# Altium schematic sheet MPPT_POWER.SchDoc
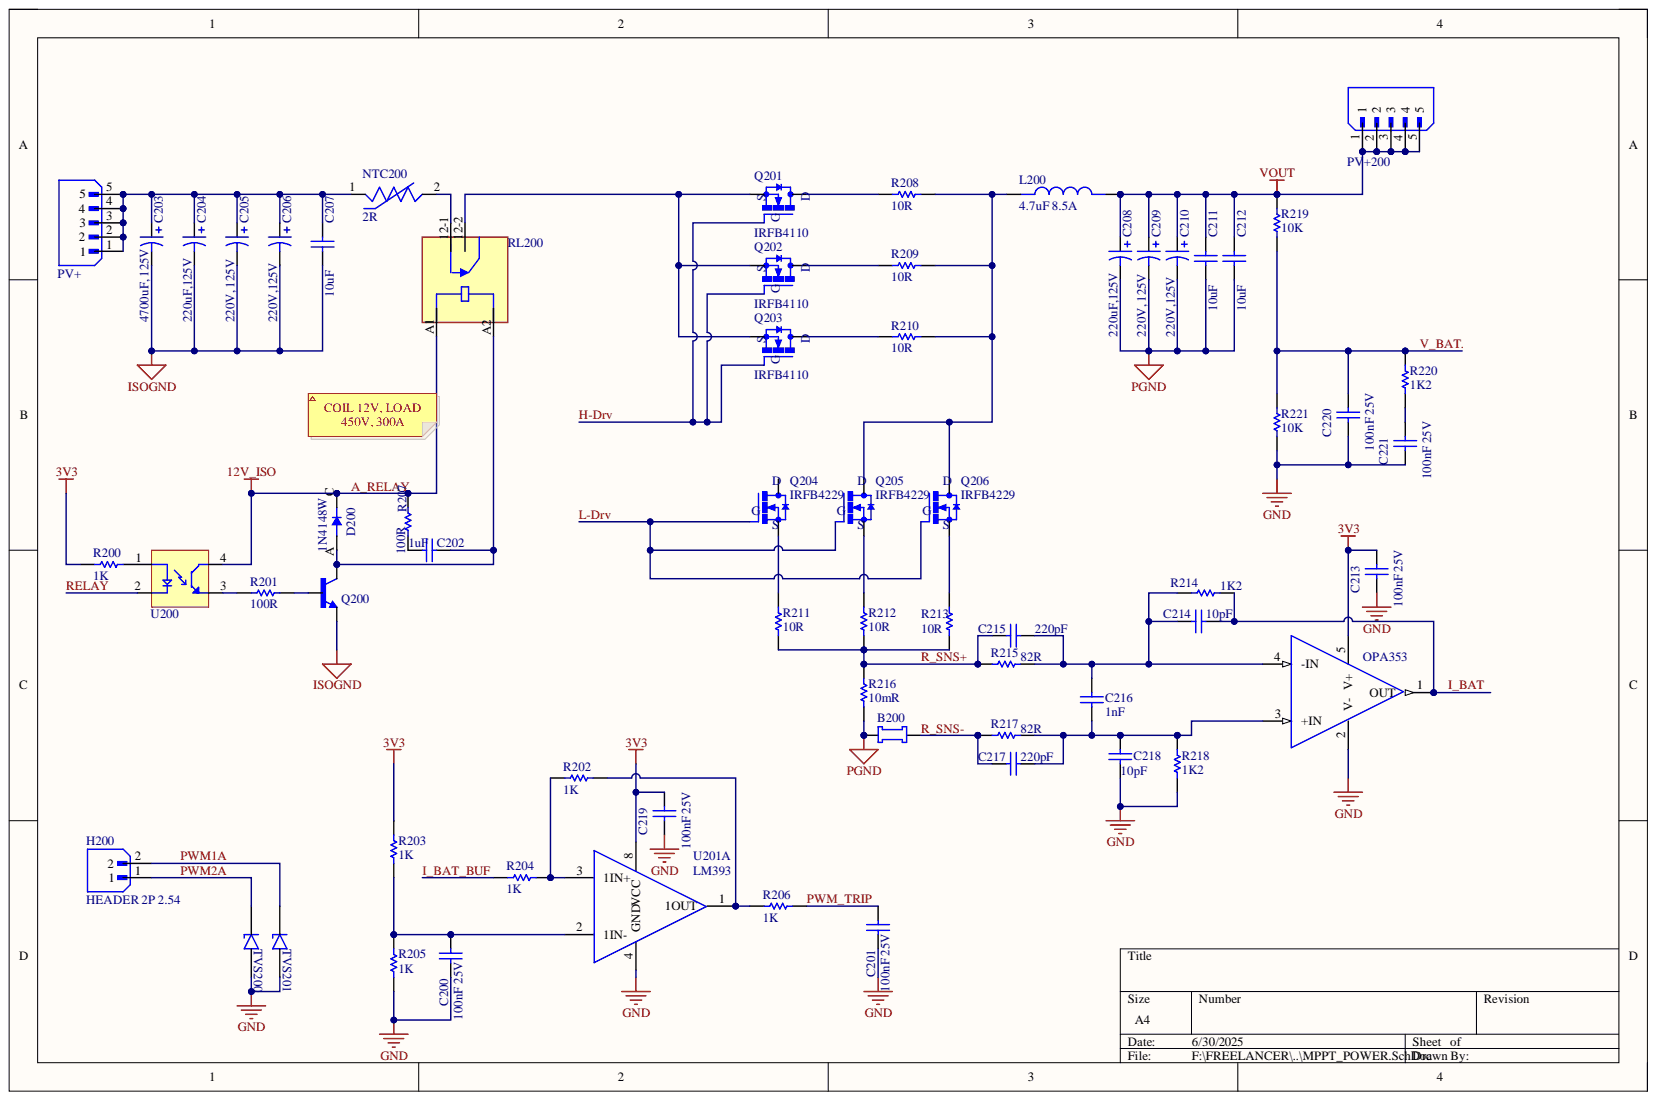
[diagram p0: MPPT_POWER.SchDoc]

## Parts
*  OPA353
B200  Narrow Neck Bridge  [Narrow Bridge]  (value not drawn)
C200  100nF 25V  [Cap cer 0603]
C201  100nF 25V  [Cap cer 0603]
C202  1uF  [Cap cer 0603]
C203  value 4700uF, 125V  [C0805]  (hidden comment: Cap Pol3)
C204  value 220uF,125V  [C0805]  (hidden comment: Cap Pol3)
C205  value 220V, 125V  [C0805]  (hidden comment: Cap Pol3)
C206  value 220V,125V  [C0805]  (hidden comment: Cap Pol3)
C207  10uF  [Cap cer 0603]
C208  value 220uF,125V  [C0805]  (hidden comment: Cap Pol3)
C209  value 220V, 125V  [C0805]  (hidden comment: Cap Pol3)
C210  value 220V,125V  [C0805]  (hidden comment: Cap Pol3)
C211  10uF  [Cap cer 0603]
C212  10uF  [Cap cer 0603]
C213  100nF 25V  [Cap cer 0603]
C214  10pF  [Cap cer 0603]
C215  220pF  [Cap cer 0603]
C216  1nF  [Cap cer 0603]
C217  220pF  [Cap cer 0603]
C218  10pF  [Cap cer 0603]
C219  100nF 25V  [Cap cer 0603]
C220  100nF 25V  [Cap cer 0603]
C221  100nF 25V  [Cap cer 0603]
D200  1N4148W  [1N4148]
H200  HEADER 2P 2.54  [HEADER 2P 2.544]
L200  4.7uF 8.5A  [Inductor 4.7uF 8.5A]
NTC200  2R
PV+  B5B-XH-A(LF)(SN)  [XH2.54 5p]  (value not drawn)
PV+200  B5B-XH-A(LF)(SN)  [XH2.54 5p]  (value not drawn)
Q200  MMBT3904  [MMBT3904]  (value not drawn)
Q201  IRFB4110
Q202  IRFB4110
Q203  IRFB4110
Q204  IRFB4229
Q205  IRFB4229
Q206  IRFB4229
R200  1K  [Res 0603]
R201  100R  [Res 0603]
R202  1K  [Res 0603]
R203  1K  [Res 0603]
R204  1K  [Res 0603]
R205  1K  [Res 0603]
R206  1K  [Res 0603]
R207  100R  [Res 0603]
R208  10R  [Res 0603]
R209  10R  [Res 0603]
R210  10R  [Res 0603]
R211  10R  [Res 0603]
R212  10R  [Res 0603]
R213  10R  [Res 0603]
R214  1K2  [Res 0603]
R215  82R  [Res 0603]
R216  10mR  [Res 0603]
R217  82R  [Res 0603]
R218  1K2  [Res 0603]
R219  10K  [Res 0603]
R220  1K2  [Res 0603]
R221  10K  [Res 0603]
RL200  (no value)
TVS200  SMCJ5.0A-13-F  [TVS 5V]  (value not drawn)
TVS201  SMCJ5.0A-13-F  [TVS 5V]  (value not drawn)
U200  PC817X1NIP1B  [PC817]  (value not drawn)
U201  LM393  [lm393]  (part A)

## Nets
3V3: *.5(V+) C213.2 C219.2 R200.1 R203.2 U201.8(VCC)
GND: *.2(V-) C200.1 C201.1 C213.1 C218.2 C219.1 C220.1 C221.1 R205.1 R218.1 R221.1 TVS200.2 TVS201.2 U201.4(GND)
ISOGND: C203.2 C204.2 C205.2 C206.2 C207.2 Q200.2
PGND: B200.1 C208.2 C209.2 C210.2 C211.2 C212.2 R216.2
VOUT: C208.1 C209.1 C210.1 C211.1 C212.1 L200.2 PV+200.1 PV+200.2 PV+200.3 PV+200.4 PV+200.5 R219.2
A_RELAY: D200.C R207.2 RL200.A1 U200.4
H-Drv: Q201.1 Q202.1 Q203.1
I_BAT: *.1(OUT) C214.2 R214.1
I_BAT_BUF: R204.1
L-Drv: Q204.1 Q205.1 Q206.1
PWM1A: H200.2 TVS201.1
PWM2A: H200.1 TVS200.1
PWM_TRIP: C201.2 R206.2
R_SNS+: C215.2 R211.1 R212.1 R213.1 R215.1 R216.1
R_SNS-: B200.2 C217.2 R217.1
RELAY: U200.2
V_BAT.: C220.2 R219.1 R220.1 R221.2
Net*_3: *.3(+IN) C216.2 C217.1 C218.1 R217.2 R218.2
Net*_4: *.4(-IN) C214.1 C215.1 C216.1 R214.2 R215.2
NetC200_2: C200.2 R203.1 R205.2 U201.2(1IN-)
NetC202_1: C202.1 D200.A Q200.3 RL200.A2
NetC202_2: C202.2 R207.1
NetC203_1: C203.1 C204.1 C205.1 C206.1 C207.1 NTC200.1 PV+.1 PV+.2 PV+.3 PV+.4 PV+.5
NetC221_2: C221.2 R220.2
NetL200_1: L200.1 Q205.2 Q206.2 R208.2 R209.2 R210.2
NetNTC200_2: NTC200.2 RL200.12-1
NetQ200_1: Q200.1 R201.2
NetQ201_2: Q201.2 R208.1
NetQ201_3: Q201.3 Q202.3 Q203.3 RL200.12-2
NetQ202_2: Q202.2 R209.1
NetQ203_2: Q203.2 R210.1
NetQ204_3: Q204.3 R211.2
NetQ205_3: Q205.3 R212.2
NetQ206_3: Q206.3 R213.2
NetR200_2: R200.2 U200.1
NetR201_1: R201.1 U200.3
NetR202_1: R202.1 R204.2 U201.3(1IN+)
NetR202_2: R202.2 R206.1 U201.1(1OUT)

Not connected: Q204 2

## Library data of the parts
C200-C202 C207 C211-C221 = GCM188R71E104KA57D: Multilayer Ceramic Capacitor, 0.1 uF, 25 V, ï¿½ 10%, X7R, 0603 [1608 Metric]; Manufacturer: Murata; Category: Ceramic Capacitors; Component Kind: Standard; ComponentLink1Description: https://datasheet.ciiva.com/18183/getdatasheetpartid-301637-18183609.pdf?src-supplier=Verical; ComponentLink1URL: https://datasheet.ciiva.com/18183/getdatasheetpartid-301637-18183609.pdf?src-supplier=Verical; ComponentLink2Description: https://datasheet.ciiva.com/3778/11371gcm188r71e104ka5723-3778030.pdf?src-supplier=Arrow+Electronics; ComponentLink2URL: https://datasheet.ciiva.com/3778/11371gcm188r71e104ka5723-3778030.pdf?src-supplier=Arrow+Electronics; ComponentLink3Description: https://datasheet.ciiva.com/6270/s0717e-6270619.pdf?src-supplier=Digikey; ComponentLink3URL: https://datasheet.ciiva.com/6270/s0717e-6270619.pdf?src-supplier=Digikey; ComponentLink4Description: https://datasheet.ciiva.com/6270/s0717e-6270620.pdf?src-supplier=Digikey; ComponentLink4URL: https://datasheet.ciiva.com/6270/s0717e-6270620.pdf?src-supplier=Digikey; ComponentLink5Description: https://datasheet.ciiva.com/6270/s0717e-6270621.pdf?src-supplier=Digikey; ComponentLink5URL: https://datasheet.ciiva.com/6270/s0717e-6270621.pdf?src-supplier=Digikey; Lead Free: 5697LeadFree; Library Name: POWER_PIN_CAMERA.SchLib; Manufacturer Part Number: GCM188R71E104KA57D; Pricing 1: 1=0.26, 10=0.151, 50=0.151, 100=0.097, 1000=0.068, 10000=0.046 (USD); RoHS: Yes; Stock 1: 52188; Supplier 1: Mouser; Supplier Part Number 1: 81-GCM188R71E104KA7D
C203-C206 C208-C210 = Cap Pol3: Polarized Capacitor (Surface Mount); Component Kind: Standard; LatestRevisionDate: 29-May-2009; LatestRevisionNote: IPC-7351 Footprint Added.; Library Name: Miscellaneous Devices.IntLib; PackageDescription: Chip Capacitor; PackageReference: C0805; Published: 8-Jun-2000; Signal Integrity: Cap Pol; Simulation: CAP; Publisher: Altium Limited
D200 = 1N4148W-7-F: Small Signal Diode, Single, 100 V, 300 Ma, 1.25 V, 4 Ns, 2 A Rohs Compliant: Yes; Manufacturer: Diodes; Average Rectified Current: 300mA; Capacitance: 2pF; Current Rating: 150mA; Forward Voltage: 1.25V; Max Forward Surge Current (Ifsm): 2A; Max Repetitive Reverse Voltage (Vrrm): 100V; Max Reverse Current: 1uA; Polarity: Standard; Power Dissipation: 400mW; Recovery Time: 4ns; Voltage Rating (DC): 75V; Category: Rectifier Diodes; Component Kind: Standard; ComponentLink1Description: https://datasheet.ciiva.com/8303/ds30086-8303197.pdf?src-supplier=Avnet; ComponentLink1URL: https://datasheet.ciiva.com/8303/ds30086-8303197.pdf?src-supplier=Avnet; ComponentLink2Description: https://datasheet.ciiva.com/911/ds30086-911735.pdf?src-supplier=Digikey; ComponentLink2URL: https://datasheet.ciiva.com/911/ds30086-911735.pdf?src-supplier=Digikey; ComponentLink3Description: https://datasheet.ciiva.com/911/ds30086-911736.pdf?src-supplier=Digikey; ComponentLink3URL: https://datasheet.ciiva.com/911/ds30086-911736.pdf?src-supplier=Digikey; ComponentLink4Description: https://datasheet.ciiva.com/911/ds30086-911734.pdf?src-supplier=Digikey; ComponentLink4URL: https://datasheet.ciiva.com/911/ds30086-911734.pdf?src-supplier=Digikey; ComponentLink5Description: https://datasheet.ciiva.com/1179/1915529-1179304.pdf?src-supplier=Element14; ComponentLink5URL: https://datasheet.ciiva.com/1179/1915529-1179304.pdf?src-supplier=Element14; ComponentLink6Description: https://datasheet.ciiva.com/22695/1915529-22695239.pdf?src-supplier=Element14; ComponentLink6URL: https://datasheet.ciiva.com/22695/1915529-22695239.pdf?src-supplier=Element14; ComponentLink7Description: https://datasheet.ciiva.com/8300/ds30086-8300392.pdf?src-supplier=Avnet; ComponentLink7URL: https://datasheet.ciiva.com/8300/ds30086-8300392.pdf?src-supplier=Avnet; ComponentLink8Description: https://datasheet.ciiva.com/15219/getdatasheetpartid-110030-15219548.pdf?src-supplier=Verical; ComponentLink8URL: https://datasheet.ciiva.com/15219/getdatasheetpartid-110030-15219548.pdf?src-supplier=Verical; ComponentLink9Description: https://datasheet.ciiva.com/4435/ds30086-4435128.pdf?src-supplier=Mouser; ComponentLink9URL: https://datasheet.ciiva.com/4435/ds30086-4435128.pdf?src-supplier=Mouser; Forward Current: 150mA; Height: 1.25mm; Lead Free: Lead Free; Length: 2.85mm; Library Name: POWER_PIN_CAMERA.SchLib; Manufacturer Part Number: 1N4148W-7-F; Max Operating Temperature: 150°C; Max Reverse Voltage (DC): 100V; Max Surge Current: 2A; Min Operating Temperature: -65°C; Mount: Surface Mount; Number of Pins: 2; Output Current: 150mA; Package Quantity: 3000; Packaging: Tape and Reel; Peak Non-Repetitive Surge Current: 2A; Power Rating: 400mW; Pricing 1: 9000=0.0099, 15000=0.0099, 21000=0.0099, 30000=0.0099, 75000=0.0099 (USD); Radiation Hardening: No; Reach SVHC Compliant: No; Reverse Recovery Time: 4ns; Reverse Recovery Time-Max: 4ns; Reverse Voltage: 100V; RoHS: Yes; RoHS Compliant: Yes; Series: -; Stock 1: 4485000; Supplier 1: Arrow Electronics; Supplier Part Number 1: 1N4148W-7-F; Voltage: 100V; Weight: 0.000353oz; Width: 1.7mm
H200 = B2B-XH-A(LF)(SN): CONNECTOR HEADER, XH SERIES, 2 POSITION, 2.50MM, Press-Fit Solder, Detent Lock, Natural; Manufacturer: JST; Contact Resistance: 20mR; Current Rating: 3A; Insulation Resistance: 1GR; Max Voltage Rating (AC): 250V; Number of Contacts: 2; Pitch: 2.5mm; Wire/Cable Gauge: 22AWG; Category: Headers and Wire Housings; Color: Natural; Component Kind: Standard; ComponentLink1Description: https://datasheet.ciiva.com/11746/0900766b8082653e-11746979.pdf?src-supplier=Rs; ComponentLink1URL: https://datasheet.ciiva.com/11746/0900766b8082653e-11746979.pdf?src-supplier=Rs; ComponentLink2Description: https://datasheet.ciiva.com/26894/getdatasheetpartid-385291-26894760.pdf?src-supplier=Verical; ComponentLink2URL: https://datasheet.ciiva.com/26894/getdatasheetpartid-385291-26894760.pdf?src-supplier=Verical; ComponentLink3Description: https://datasheet.ciiva.com/26894/getdatasheetpartid-640357-26894434.pdf?src-supplier=Verical; ComponentLink3URL: https://datasheet.ciiva.com/26894/getdatasheetpartid-640357-26894434.pdf?src-supplier=Verical; ComponentLink4Description: https://datasheet.ciiva.com/8052/5448-8052024.pdf?src-supplier=Element14; ComponentLink4URL: https://datasheet.ciiva.com/8052/5448-8052024.pdf?src-supplier=Element14; Contact Material: Brass; Contact Plating: Tin,Lead,Copper; Contact Type: Male Pin; Depth: 5.75mm; Fastening Type: Detent; Housing Color: White; Housing Material: Polyamide; Lead Free: Lead Free; Lead Pitch: 2.5mm; Length: 7.4mm; Manufacturer Part Number: B2B-XH-A(LF)(SN); Max Current Rating: 3A; Max Operating Temperature: 85°C; Max Voltage Rating (DC): 250V; Min Operating Temperature: -25°C; Mount: Through Hole; Number of Positions: 2; Number of Rows: 1; Orientation: Straight; Packaging: Bulk; Pricing 1: 1=0,0544, 500=0,0528, 1500=0,0514, 5000=0,0499, 15000=0,0472 (USD); Reach SVHC Compliant: No; RoHS: Yes; Series: XH; Stock 1: 138390; Supplier 1: Future Electronics; Supplier Part Number 1: 1638840; Termination: Solder; Voltage Rating: 250V; Voltage Rating (AC): 250V; Weight: 28.349523g; Library Name: MPPT_600W.SchLib
L200 = Inductor 4.7uF 8.5A: FIXED IND 4.7UH 8.5A 18.4MOHM; Manufacturer: Abracon; Manufacturer Part Number: ASPIAIG-F6050-4R7M-T; Category: Fixed Inductors; Component Kind: Standard; ComponentLink1Description: https://datasheet.octopart.com/ASPIAIG-F6050-4R7M-T-Abracon-datasheet-113089578.pdf?src-supplier=Future+Electronics; ComponentLink1URL: https://datasheet.octopart.com/ASPIAIG-F6050-4R7M-T-Abracon-datasheet-113089578.pdf?src-supplier=Future+Electronics; ComponentLink2Description: https://abracon.com/Magnetics/power/ASPIAIG-F.pdf?src-supplier=Digikey; ComponentLink2URL: https://abracon.com/Magnetics/power/ASPIAIG-F.pdf?src-supplier=Digikey; Library Name: POWER_PIN_CAMERA.SchLib; Pricing 1: 1=1.3, 10=1.07, 50=1.07, 100=0.828, 1000=0.668, 10000=0.625 (USD); RoHS: No; Stock 1: 897; Supplier 1: Mouser; Supplier Part Number 1: 815-SPIAIGF60504.7MT
NTC200 = NTC: Component Kind: Standard; Library Name: MPPT_600W.SchLib
PV+ PV+200 = B5B-XH-A(LF)(SN): Connector Header, XH Series, 5 Position, 2.50mm, Through Hole, Press-Fit, Solder, Natural; Manufacturer: JST; Contact Post Length: 0.134inch; Gender: 29Gender; Mated Stacking Heights: 9.8mm; Number of Positions: 5; Voltage Rating: 250V; Category: Headers and Wire Housings; Component Kind: Standard; ComponentLink1Description: https://datasheet.ciiva.com/26894/getdatasheetpartid-455618-26894798.pdf?src-supplier=Verical; ComponentLink1URL: https://datasheet.ciiva.com/26894/getdatasheetpartid-455618-26894798.pdf?src-supplier=Verical; ComponentLink2Description: https://datasheet.ciiva.com/11746/0900766b8082f55f-11746654.pdf?src-supplier=Rs; ComponentLink2URL: https://datasheet.ciiva.com/11746/0900766b8082f55f-11746654.pdf?src-supplier=Rs; ComponentLink3Description: https://datasheet.ciiva.com/26894/getdatasheetpartid-640991-26894479.pdf?src-supplier=Verical; ComponentLink3URL: https://datasheet.ciiva.com/26894/getdatasheetpartid-640991-26894479.pdf?src-supplier=Verical; ComponentLink4Description: https://datasheet.ciiva.com/724/exh-724390.pdf?src-supplier=Digikey; ComponentLink4URL: https://datasheet.ciiva.com/724/exh-724390.pdf?src-supplier=Digikey; ComponentLink5Description: https://datasheet.ciiva.com/8052/1684882-8052063.pdf?src-supplier=Element14; ComponentLink5URL: https://datasheet.ciiva.com/8052/1684882-8052063.pdf?src-supplier=Element14; Connector Type: Header; Contact Finish - Mating: TIN; Contact Material: Brass; Contact Shape: Square; Contact Type: Male Pin; Fastening Type: Detent; Flammability Rating: UL94 V-0; Insulation Color: Natural; Insulation Height: 0.276inch; Insulation Material: Nylon; Lead Free: Lead Free; Manufacturer Part Number: B5B-XH-A(LF)(SN); Max Operating Temperature: 85°C; Min Operating Temperature: -25°C; Mount: Through Hole; Number of Rows: 1; Packaging: Bulk; Pricing 1: 1=0,106, 250=0,103, 1000=0,0996, 2500=0,0972, 10000=0,0916 (USD); RoHS: Yes; Series: XH; Stock 1: 3816; Supplier 1: Future Electronics; Supplier Part Number 1: 5006945; Termination: Press-Fit; Weight: 28.349523g; Library Name: MPPT_600W.SchLib
Q200 = MMBT3904: MMBT3904 Series 40 V CE Breakdown 0.2 A NPN General Purpose Amplifier - SOT-23; Manufacturer: onsemi; Collector Base Voltage (VCBO): 60V; Collector Emitter Breakdown Voltage: 40V; Collector Emitter Saturation Voltage: 300mV; Current Rating: 200mA; Emitter Base Voltage (VEBO): 6V; hFE Min: 100; Max Power Dissipation: 350mW; Transition Frequency: 300MHz; Case/Package: SOT-23; Category: BJTs; Collector Emitter Voltage (VCEO): 40V; Collector-emitter Voltage-Max: 300mV; Component Kind: Standard; ComponentLink1Description: http://www.farnell.com/datasheets/2304127.pdf?src-supplier=Farnell; ComponentLink1URL: http://www.farnell.com/datasheets/2304127.pdf?src-supplier=Farnell; ComponentLink2Description: http://www.onlinecomponents.com/datasheet/mmbt3904.aspx?p=11366981&src-supplier=Onlinecomponents.com; ComponentLink2URL: http://www.onlinecomponents.com/datasheet/mmbt3904.aspx?p=11366981&src-supplier=Onlinecomponents.com; ComponentLink3Description: http://components.arrow.com/part/dataview/201938S7901518N7769?src-supplier=Arrow+Electronics; ComponentLink3URL: http://components.arrow.com/part/dataview/201938S7901518N7769?src-supplier=Arrow+Electronics; ComponentLink4Description: http://www.produktinfo.conrad.com/datenblaetter/1200000-1299999/001264825-da-01-en-TRANSISTOR_GP_NPN_MMBT3904_SOT_23_3_FSC.pdf?src-supplier=Conrad; ComponentLink4URL: http://www.produktinfo.conrad.com/datenblaetter/1200000-1299999/001264825-da-01-en-TRANSISTOR_GP_NPN_MMBT3904_SOT_23_3_FSC.pdf?src-supplier=Conrad; Lead Free: Lead Free; Manufacturer Part Number: MMBT3904; Max Breakdown Voltage: 40V; Max Collector Current: 200mA; Max Operating Temperature: 150°C; Min Operating Temperature: -55°C; Mount: Surface Mount; Number of Pins: 3; Package Quantity: 1; Packaging: Tape and Reel; Polarity: NPN; Pricing 1: 5=0,136, 25=0,133, 100=0,0476, 250=0,0435, 500=0,043, 1000=0,022, 5000=0,02 (GBP); Radiation Hardening: No; Reach SVHC Compliant: No; RoHS: Yes; RoHS Compliant: Yes; Series: -; Supplier 1: Farnell; Supplier Part Number 1: 9846727; Termination: SMD/SMT; Voltage Rating (DC): 40V; Weight: 0.03g; Library Name: MPPT_600W.SchLib
Q201-Q206 = MOSFET: Component Kind: Standard; Library Name: MPPT_600W.SchLib
R200-R221 = Resistor 2.2K: Res Thick Film 0603 2.2K Ohm 5% 1/10W ±200ppm/°C Molded SMD SMD Paper T/R; Manufacturer: Vishay; Manufacturer Part Number: CRCW06032K20JNEA; Max Power Dissipation: 100mW; Resistance: 2.2kR; Voltage Rating: 75V; Case Code (Imperial): 0603; Case Code (Metric): 1608; Case/Package: 0603; Category: Chip SMD Resistors; Component Kind: Standard; ComponentLink1Description: https://datasheet.ciiva.com/2462/dcrcwe3-2462048.pdf?src-supplier=Digikey; ComponentLink1URL: https://datasheet.ciiva.com/2462/dcrcwe3-2462048.pdf?src-supplier=Digikey; ComponentLink2Description: https://datasheet.ciiva.com/16767/70201119-16767464.pdf?src-supplier=Allied+Electronics; ComponentLink2URL: https://datasheet.ciiva.com/16767/70201119-16767464.pdf?src-supplier=Allied+Electronics; ComponentLink3Description: https://datasheet.ciiva.com/2462/dcrcwe3-2462046.pdf?src-supplier=Digikey; ComponentLink3URL: https://datasheet.ciiva.com/2462/dcrcwe3-2462046.pdf?src-supplier=Digikey; ComponentLink4Description: https://datasheet.ciiva.com/5541/dcrcwe3-109170-5541959.pdf?src-supplier=Mouser; ComponentLink4URL: https://datasheet.ciiva.com/5541/dcrcwe3-109170-5541959.pdf?src-supplier=Mouser; ComponentLink5Description: https://datasheet.ciiva.com/16286/dcrcwe3-16286997.pdf?src-supplier=Future+Electronics; ComponentLink5URL: https://datasheet.ciiva.com/16286/dcrcwe3-16286997.pdf?src-supplier=Future+Electronics; Construction: Rectangular; Contact Plating: Tin; Depth: 0.85mm; Features: Automotive AEC-Q200; Height: 0.45mm; Lead Free: 5697LeadFree; Length: 1.55mm; Library Name: POWER_PIN_CAMERA.SchLib; Max Operating Temperature: 155°C; Military Standard: Not; Min Operating Temperature: -55°C; Number of Terminations: 2; Package Quantity: 5000; Packaging: Tape and Reel; Power Rating: 100mW; Pricing 1: 5000=0.0053 (USD); Radiation Hardening: No; Ratings: AEC-Q200; Reach SVHC Compliant: Unknown; Resistance Tolerance: 5%; Resistor Type: Thick Film; RoHS: Yes; RoHS Compliant: Yes; Series: CRCW; Size Code: 0603; Stock 1: 130000; Supplier 1: Arrow Electronics; Supplier Part Number 1: CRCW06032K20JNEA; Temperature Coefficient: 200ppm/°C; Termination: SMD/SMT; Tolerance: 5%; Weight: 7.1E-05oz; Width: 0.85mm
RL200 = HFE-Relay: COIL 12, LOAD 450V, 300A; Component Kind: Standard; Library Name: MPPT_600W.SchLib
TVS200 TVS201 = SMCJ5.0A-13-F: SMCJ5.0A Series 1500 W 5.0 V Surface Mount Uni-Directional TVS Diode - DO-214AB; Manufacturer: Diodes; Breakdown Voltage: 6.4V; Capacitance: 7nF; Clamping Voltage: 9.2V; Diode Type: ZENER; Direction: Unidirectional; Forward Voltage: 3.5V; Leakage Current: 1mA; Max Breakdown Voltage: 7.07V; Max Output Current: 163A; Max Power Dissipation: 1.5kW; Max Surge Current: 200A; Number of Elements: 1; Power Line Protection: No; Reverse Standoff Voltage: 5V; Test Current: 10mA; Voltage Rating (DC): 51V; Case/Package: SMC; Category: TVS Diodes; Clamping Voltage-Max: 9.2V; Component Kind: Standard; ComponentLink1Description: https://datasheet.ciiva.com/336/ds19003-336384.pdf?src-supplier=Digikey; ComponentLink1URL: https://datasheet.ciiva.com/336/ds19003-336384.pdf?src-supplier=Digikey; ComponentLink2Description: https://datasheet.ciiva.com/15143/getdatasheetpartid-171808-15143868.pdf?src-supplier=Verical; ComponentLink2URL: https://datasheet.ciiva.com/15143/getdatasheetpartid-171808-15143868.pdf?src-supplier=Verical; Height: 2.62mm; Lead Free: Lead Free; Length: 7.11mm; Manufacturer Part Number: SMCJ5.0A-13-F; Max Operating Temperature: 150°C; Max Reverse Leakage Current: 1mA; Min Breakdown Voltage: 6.4V; Min Operating Temperature: -55°C; Mount: Surface Mount; Number of Pins: 2; Number of Unidirectional Channels: 1; Package Quantity: 3000; Packaging: Tape and Reel; Peak Pulse Current: 163A; Peak Pulse Power: 1.5kW; Polarity: Unidirectional; Power Dissipation: 1.5kW; Pricing 1: 1=0,72, 10=0,569, 100=0,32, 500=0,306, 1000=0,29 (USD); Radiation Hardening: No; Reverse Breakdown Voltage: 6.4V; RoHS: Yes; RoHS Compliant: Yes; Series: -; Stock 1: 6833; Supplier 1: Digikey; Supplier Part Number 1: SMCJ5.0A-FDICT-ND; Termination: SMD/SMT; Width: 6.22mm; Working Voltage: 5V; Library Name: MPPT_600W.SchLib
U200 = PC817X1NIP1B: Optocoupler Dc-in 1-CH Transistor Dc-out 4-PIN DIP SMD T/r; Manufacturer: Sharp; Number of Channels: 1NUMBER; Category: Optocouplers; Component Kind: Standard; ComponentLink1Description: https://datasheet.octopart.com/PC817X1NIP1B-Sharp-datasheet-81842616.pdf?src-supplier=TME; ComponentLink1URL: https://datasheet.octopart.com/PC817X1NIP1B-Sharp-datasheet-81842616.pdf?src-supplier=TME; Manufacturer Part Number: PC817X1NIP1B; Pricing 1: 1=0,7, 10=0,473, 50=0,473, 100=0,343, 1000=0,277, 10000=0,181 (USD); RoHS: Yes; Supplier 1: Mouser; Supplier Part Number 1: 852-PC817X1NIP1B; Library Name: MPPT_600W.SchLib
U201 = LM393DT: LM393 Series Dual 36 V 250 nA SMT Low Power Voltage Comparator - SOIC-8; Manufacturer: STMicroelectronics; Category: Comparators; Component Kind: Standard; ComponentLink1Description: https://datasheet.ciiva.com/14153/lm393-st-tds-eng-14153514.pdf?src-supplier=Distrelec; ComponentLink1URL: https://datasheet.ciiva.com/14153/lm393-st-tds-eng-14153514.pdf?src-supplier=Distrelec; ComponentLink2Description: https://datasheet.ciiva.com/1827/cd00000465-1827142.pdf?src-supplier=Digikey; ComponentLink2URL: https://datasheet.ciiva.com/1827/cd00000465-1827142.pdf?src-supplier=Digikey; ComponentLink3Description: https://datasheet.ciiva.com/1827/cd00000465-1827143.pdf?src-supplier=Digikey; ComponentLink3URL: https://datasheet.ciiva.com/1827/cd00000465-1827143.pdf?src-supplier=Digikey; ComponentLink4Description: https://datasheet.ciiva.com/1827/cd00000465-1827144.pdf?src-supplier=Digikey; ComponentLink4URL: https://datasheet.ciiva.com/1827/cd00000465-1827144.pdf?src-supplier=Digikey; ComponentLink5Description: https://datasheet.ciiva.com/2970/cd00000465-2970521.pdf?src-supplier=Avnet; ComponentLink5URL: https://datasheet.ciiva.com/2970/cd00000465-2970521.pdf?src-supplier=Avnet; ComponentLink6Description: https://datasheet.ciiva.com/4463/cd00000465-4463849.pdf?src-supplier=Mouser; ComponentLink6URL: https://datasheet.ciiva.com/4463/cd00000465-4463849.pdf?src-supplier=Mouser; ComponentLink7Description: https://datasheet.ciiva.com/8067/1689826-8067369.pdf?src-supplier=Element14; ComponentLink7URL: https://datasheet.ciiva.com/8067/1689826-8067369.pdf?src-supplier=Element14; ComponentLink8Description: https://datasheet.ciiva.com/1483/1689826-1483069.pdf?src-supplier=Element14; ComponentLink8URL: https://datasheet.ciiva.com/1483/1689826-1483069.pdf?src-supplier=Element14; Manufacturer Part Number: LM393DT; Pricing 1: 2500=0,0603, 7500=0,0589, 12500=0,0587, 17500=0,0577, 25000=0,0566, 62500=0,0562, 125000=0,0549 (USD); RoHS: Yes; Stock 1: 3735000; Supplier 1: Arrow Electronics; Supplier Part Number 1: LM393DT; Library Name: MPPT_600W.SchLib
* = OPA353: Component Kind: Standard; Library Name: MPPT_600W.SchLib
B200 = Narrow Neck Bridge: Component Kind: Standard; Library Name: POWER_PIN_CAMERA.SchLib
